# Altium schematic sheet CW551_MainBoard_Connectors.SchDoc
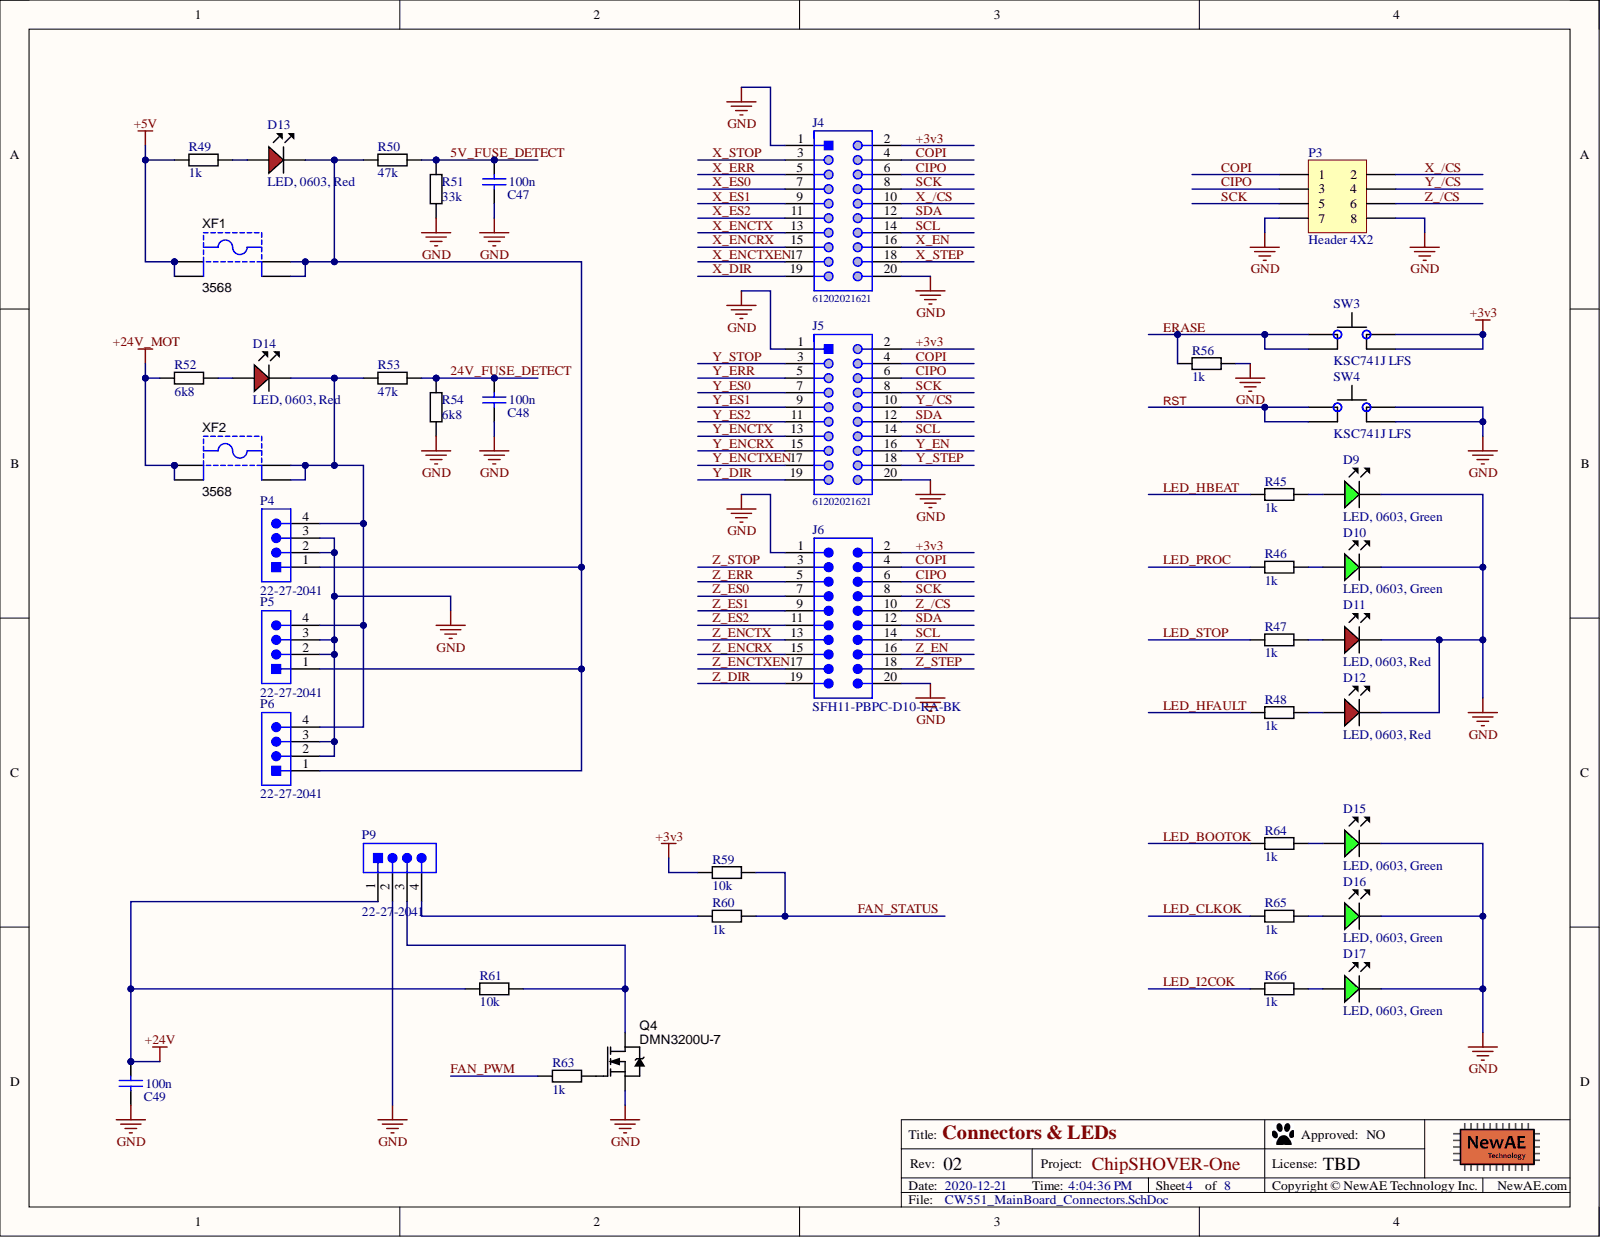
[diagram p0: CW551_MainBoard_Connectors.SchDoc]

## Parts
C47  100n  [0603]
C48  100n  [0603]
C49  100n  [0603]
D9  LED, 0603, Green  [LED-0603-GREEN]
D10  LED, 0603, Green  [LED-0603-GREEN]
D11  LED, 0603, Red  [LED-0603-RED]
D12  LED, 0603, Red  [LED-0603-RED]
D13  LED, 0603, Red  [LED-0603-RED]
D14  LED, 0603, Red  [LED-0603-RED]
D15  LED, 0603, Green  [LED-0603-GREEN]
D16  LED, 0603, Green  [LED-0603-GREEN]
D17  LED, 0603, Green  [LED-0603-GREEN]
J4  61202021621  [61202021621]
J5  61202021621  [61202021621]
J6  SFH11-PBPC-D10-RA-BK  [1" Right Angle]
P3  Header 4X2  [HDR2X4]
P4  22-27-2041  [MOLX-22-27-2041_V]
P5  22-27-2041  [MOLX-22-27-2041_V]
P6  22-27-2041  [MOLX-22-27-2041_V]
P9  22-27-2041  [MOLX-22-27-2041_V]
Q4  DMN3200U-7  [SOT23]
R45  1k  [0603]
R46  1k  [0603]
R47  1k  [0603]
R48  1k  [0603]
R49  1k  [0603]
R50  47k  [RESC1608X55N]
R51  33k  [0603]
R52  6k8  [0603]
R53  47k  [RESC1608X55N]
R54  6k8  [0603]
R56  1k  [0603]
R59  10k  [0603]
R60  1k  [0603]
R61  10k  [0603]
R63  1k  [0603]
R64  1k  [0603]
R65  1k  [0603]
R66  1k  [0603]
SW3  KSC741J LFS  [CK_KSC7J]
SW4  KSC741J LFS  [CK_KSC7J]
XF1  3568  [KSTN-3568_V]
XF2  3568  [KSTN-3568_V]

## Nets
GND: C47.2 C48.2 C49.2 D9.K D10.K D11.K D12.K D15.K D16.K D17.K J4.1 J4.20 J5.1 J5.20 J6.1 J6.20 P3.7 P3.8 P4.2 P4.3 P5.2 P5.3 P6.2 P6.3 P9.2 Q4.2 R51.2 R54.2 R56.2 SW4.2 SW4.3
5V_FUSE_DETECT: C47.1 R49.1 R50.1 R51.1 XF1.1 XF1.2
24V_FUSE_DETECT: C48.1 C49.1 P9.1 R52.1 R53.1 R54.1 R61.1 XF2.1 XF2.2
+3v3: J4.2 J5.2 J6.2 R59.1 SW3.2 SW3.3
CIPO: J4.6 J5.6 J6.6 P3.3
COPI: J4.4 J5.4 J6.4 P3.1
ERASE: R56.1 SW3.1 SW3.4
FAN_PWM: R63.1
FAN_STATUS: R59.2 R60.2
LED_BOOTOK: R64.1
LED_CLKOK: R65.1
LED_HBEAT: R45.1
LED_HFAULT: R48.1
LED_I2COK: R66.1
LED_PROC: R46.1
LED_STOP: R47.1
RST: SW4.1 SW4.4
SCK: J4.8 J5.8 J6.8 P3.5
SCL: J4.14 J5.14 J6.14
SDA: J4.12 J5.12 J6.12
X_/CS: J4.10 P3.2
X_DIR: J4.19
X_EN: J4.16
X_ENCRX: J4.15
X_ENCTX: J4.13
X_ENCTXEN: J4.17
X_ERR: J4.5
X_ES0: J4.7
X_ES1: J4.9
X_ES2: J4.11
X_STEP: J4.18
X_STOP: J4.3
Y_/CS: J5.10 P3.4
Y_DIR: J5.19
Y_EN: J5.16
Y_ENCRX: J5.15
Y_ENCTX: J5.13
Y_ENCTXEN: J5.17
Y_ERR: J5.5
Y_ES0: J5.7
Y_ES1: J5.9
Y_ES2: J5.11
Y_STEP: J5.18
Y_STOP: J5.3
Z_/CS: J6.10 P3.6
Z_DIR: J6.19
Z_EN: J6.16
Z_ENCRX: J6.15
Z_ENCTX: J6.13
Z_ENCTXEN: J6.17
Z_ERR: J6.5
Z_ES0: J6.7
Z_ES1: J6.9
Z_ES2: J6.11
Z_STEP: J6.18
Z_STOP: J6.3
NetD9_A: D9.A R45.2
NetD10_A: D10.A R46.2
NetD11_A: D11.A R47.2
NetD12_A: D12.A R48.2
NetD13_A: D13.A R49.2
NetD13_K: D13.K P4.1 P5.1 P6.1 R50.2 XF1.3 XF1.4
NetD14_A: D14.A R52.2
NetD14_K: D14.K P4.4 P5.4 P6.4 R53.2 XF2.3 XF2.4
NetD15_A: D15.A R64.2
NetD16_A: D16.A R65.2
NetD17_A: D17.A R66.2
NetP9_3: P9.3 Q4.3 R61.2
NetP9_4: P9.4 R60.1
NetQ4_1: Q4.1 R63.2

## Library data of the parts
C47-C49 = CCHIP-100n-0603: 100n, 0603; Manufacture 1: Kemet; Manufacture Part Number 1: C0603C104K5RACTU; Case-Metric: 1608; Component Group: Capacitor; Database Table Name: main; Rated Voltage: 50VDC; Case-EIA: 0603; Component Kind: Standard; Component Type: Standard; LCSC Equivalent: C1591; Library Name: NewAE_AltiumLib.DBLib; Mounting Technology: SMT; Part Number: CCHIP-100n-0603; Pin Count: 2; Supplier 1: Digi-Key; Supplier Part Number 1: 399-5089-2-ND; Value: 100n
D9 D10 D15-D17 = LEDCHIP_0603_GREEN: Chip LED, Green; Manufacture 1: Kingbright; Manufacture Part Number 1: APT1608CGCK; Component Group: Optical; Database Table Name: main; PP Material Stack: 16; PP Rotation: -90; Component Kind: Standard; Component Type: Standard; LCSC Equivalent: C192666; Library Name: NewAE_AltiumLib.DBLib; Mounting Technology: SMT; Part Number: LEDCHIP_0603_GREEN; Pin Count: 2; Supplier 1: Digi-Key; Supplier Part Number 1: 754-1116-1-ND
D11-D14 = LEDCHIP_0603_RED: Chip LED, Red; Manufacture 1: Kingbright; Manufacture Part Number 1: APT1608SRCPRV; Component Group: Optical; Database Table Name: main; PP Material Stack: 15; PP Rotation: -90; Component Kind: Standard; Component Type: Standard; LCSC Equivalent: C192315; Library Name: NewAE_AltiumLib.DBLib; Mounting Technology: SMT; Part Number: LEDCHIP_0603_RED; Pin Count: 2; Supplier 1: Digi-Key; Supplier Part Number 1: 754-1122-1-ND
J4 J5 = CMP-1502-00010-1: Male Box Header WR-BHD, THT, Vertical, pitch 2.54 mm, 20 pins; Manufacturer: Wurth Elektronik; Contact Resistance: 20mOhm; Pin Number: 20; Pitch: 2.54mm; Withstanding Voltage: 500VAC; Working Voltage: 250VAC; Component Kind: Standard; Datasheet URL: https://katalog.we-online.de/em/datasheet/6120xx21621.pdf; Gender: Male; Library Name: Altium Content Vault; Manufacturer URL: http://www.we-online.de; Mounting Technology: THT; Operating Temperature: -40to125degC; Orientation: Vertical; Package Reference: 61202021621; Packaging: Tray; Part Number: 61202021621; Published Date: August2018; Publisher: WurthElektronik
J6 = SFH11-PBPC-D10-RA-BK: CONN HEADR FMALE 20PS .1" R/A AU; Manufacture 1: Sullins Connector Solutions; Component Group: Connector; Database Table Name: main; PP Material Stack: 0; Component Kind: Standard; Component Type: Standard; Library Name: NewAE_AltiumLib.DBLib; Manufacture Part Number 1: SFH11-PBPC-D10-RA-BK; Part Number: SFH11-PBPC-D10-RA-BK; Pin Count: 20; PP Rotation: 0; Supplier 1: Digi-Key; Supplier Part Number 1: S9205-ND
P3 = Header 4X2: Header, 4-Pin, Dual row; Component Kind: Standard; LatestRevisionDate: 17-Jul-2002; LatestRevisionNote: Re-released for DXP Platform.; Library Name: Miscellaneous Connectors.IntLib; Signal Integrity: Connector; Publisher: Altium Limited
P4-P6 P9 = CMP-2000-05243-1: Male Header, Pitch 2.54 mm, 1 x 4 Position, Height 11.7 mm, Tail Length 3.56 mm, RoHS, Bulk; Manufacturer: Molex; Current rating: 4A; Voltage rating: 250V; Component Kind: Standard; Contact material: Tinplatedbrass; Datasheet URL: http://www.molex.com/pdm_docs/sd/022272041_sd.pdf; Datasheet Version: Rev. F, 06/2009; Housing material: Nylon(UL94V-0); Library Name: Altium Content Vault; Manufacturer URL: http://www.molex.com/; Operating temperature: 0degCto+75degC
Q4 = DMN3200U-7: MOSFET N-CH 30V 2.2A SOT23-3; Manufacture 1: Diodes Inc.; Database Table Name: main; PP Material Stack: 0; Rated Power: 3A; Component Group: MOSFET; Component Kind: Standard; Component Type: Standard; LCSC Equivalent: C461018; Library Name: NewAE_AltiumLib.DBLib; Manufacture Part Number 1: DMN3200U-7; Mounting Technology: SMT; Part Number: DMN3200U-7; Pin Count: 3; PP Rotation: 0; Rated Voltage: 30V; RoHS: Yes; Supplier 1: Digi-Key; Supplier Part Number 1: DMN3200U-7DICT-ND
R45-R49 R56 R60 R63-R66 = RCHIP-1K-0603: 1k res, 0603, 1%, 1/10W; Manufacture 1: Yageo; Manufacture Part Number 1: RC0603FR-071KL; Case-Metric: 1608; Component Group: Resistor; Database Table Name: main; Rated Power: 0.1W; Rated Voltage: 75VDC; Case-EIA: 0603; Component Kind: Standard; Component Type: Standard; Library Name: NewAE_AltiumLib.DBLib; Mounting Technology: SMT; Part Number: RCHIP-1K-0603; Pin Count: 2; Supplier 1: Digi-Key; Supplier Part Number 1: 311-1.00KHRCT-ND; Temperature Range: -55C to 155C; Tolerance: 1%; Value: 1k
R50 R53 = RCHIP-47K-0603: 47k res, 0603, 1%, 1/10W; Manufacture 1: Yageo; Manufacture Part Number 1: RC0603FR-0747KL; Case-EIA: 0603; Case-Metric: 1608; Component Group: Resistor; Rated Power: 0.1W; Rated Voltage: 75VDC; 0_TEMP: RESC1608X55N; Component Kind: Standard; Component Type: Standard; Library Name: CW550_MAIN.SCHLIB; Mounting Technology: SMT; Part Number: RCHIP-47K-0603; Pin Count: 2; Supplier 1: Digi-Key; Supplier Part Number 1: 311-47.0KHRCT-ND; Temperature Range: -55C to 155C; Tolerance: 1%; Value: 47k
R51 = RCHIP-33k-0603: 33k res, 0603, 1%, 1/10W; Manufacture 1: Yageo; Manufacture Part Number 1: RC0603FR-0733KL; Case-Metric: 1608; Component Group: Resistor; Database Table Name: main; Rated Power: 0.1W; Rated Voltage: 75VDC; Case-EIA: 0603; Component Kind: Standard; Component Type: Standard; Library Name: NewAE_AltiumLib.DBLib; Mounting Technology: SMT; Part Number: RCHIP-33K-0603; Pin Count: 2; Supplier 1: Digi-Key; Supplier Part Number 1: 311-33.0KHRCT-ND; Temperature Range: -55C to 155C; Tolerance: 1%; Value: 33k
R52 R54 = RCHIP-6K8-0603: 6k8 res, 0603, 1%, 1/10W; Manufacture 1: Yageo; Manufacture Part Number 1: RC0603FR-076K8L; Case-Metric: 1608; Component Group: Resistor; Database Table Name: main; Rated Power: 0.1W; Rated Voltage: 75VDC; Case-EIA: 0603; Component Kind: Standard; Component Type: Standard; Library Name: NewAE_AltiumLib.DBLib; Mounting Technology: SMT; Part Number: RCHIP-6K8-0603; Pin Count: 2; Supplier 1: Digi-Key; Supplier Part Number 1: 311-6.80KHRCT-ND; Temperature Range: -55C to 155C; Tolerance: 1%; Value: 6k8
R59 R61 = RCHIP-10K-0603: 10k res, 0603, 1%, 1/10W; Manufacture 1: Yageo; Manufacture Part Number 1: RC0603FR-0710KL; Case-Metric: 1608; Component Group: Resistor; Database Table Name: main; Rated Power: 0.1W; Rated Voltage: 75VDC; Case-EIA: 0603; Component Kind: Standard; Component Type: Standard; Library Name: NewAE_AltiumLib.DBLib; Mounting Technology: SMT; Part Number: RCHIP-10K-0603; Pin Count: 2; Supplier 1: Digi-Key; Supplier Part Number 1: 311-10.0KHRCT-ND; Temperature Range: -55C to 155C; Tolerance: 1%; Value: 10k
SW3 SW4 = TACTSW-KSC741J: SWITCH TACTILE SPST-NO 0.01A 32V; Manufacture 1: C&K; Database Table Name: main; PP Material Stack: 0; Component Group: Switch; Component Kind: Standard; Component Type: Standard; LCSC Equivalent: C221766; Library Name: NewAE_AltiumLib.DBLib; Manufacture Part Number 1: KSC741J LFS; Mounting Technology: SMT; Part Number: TACTSW-KSC741J; Pin Count: 4; PP Rotation: 0; RoHS: Yes; Supplier 1: Digi-Key; Supplier Part Number 1: CKN10309CT-ND
XF1 XF2 = CMP-2000-05464-1: Fuse Holder, 20 A, 500 V, -50 to 145 degC, 4-Pin THD, RoHS; Manufacturer: Keystone; Component Kind: Standard; Datasheet URL: https://datasheet.ciiva.com/16294/m60-2p40-16294706.pdf; Datasheet Version: 04/2013; Library Name: Altium Content Vault; Manufacturer URL: http://www.keyelco.com/; Mounting Technology: ThroughHole; Package Description: 4-Pin Through Hole Device, Body 16 x 6.73 mm, Pitch 9.9 mm; Package Reference: 3568
